# Altium schematic sheet BaseFunctions.SchDoc
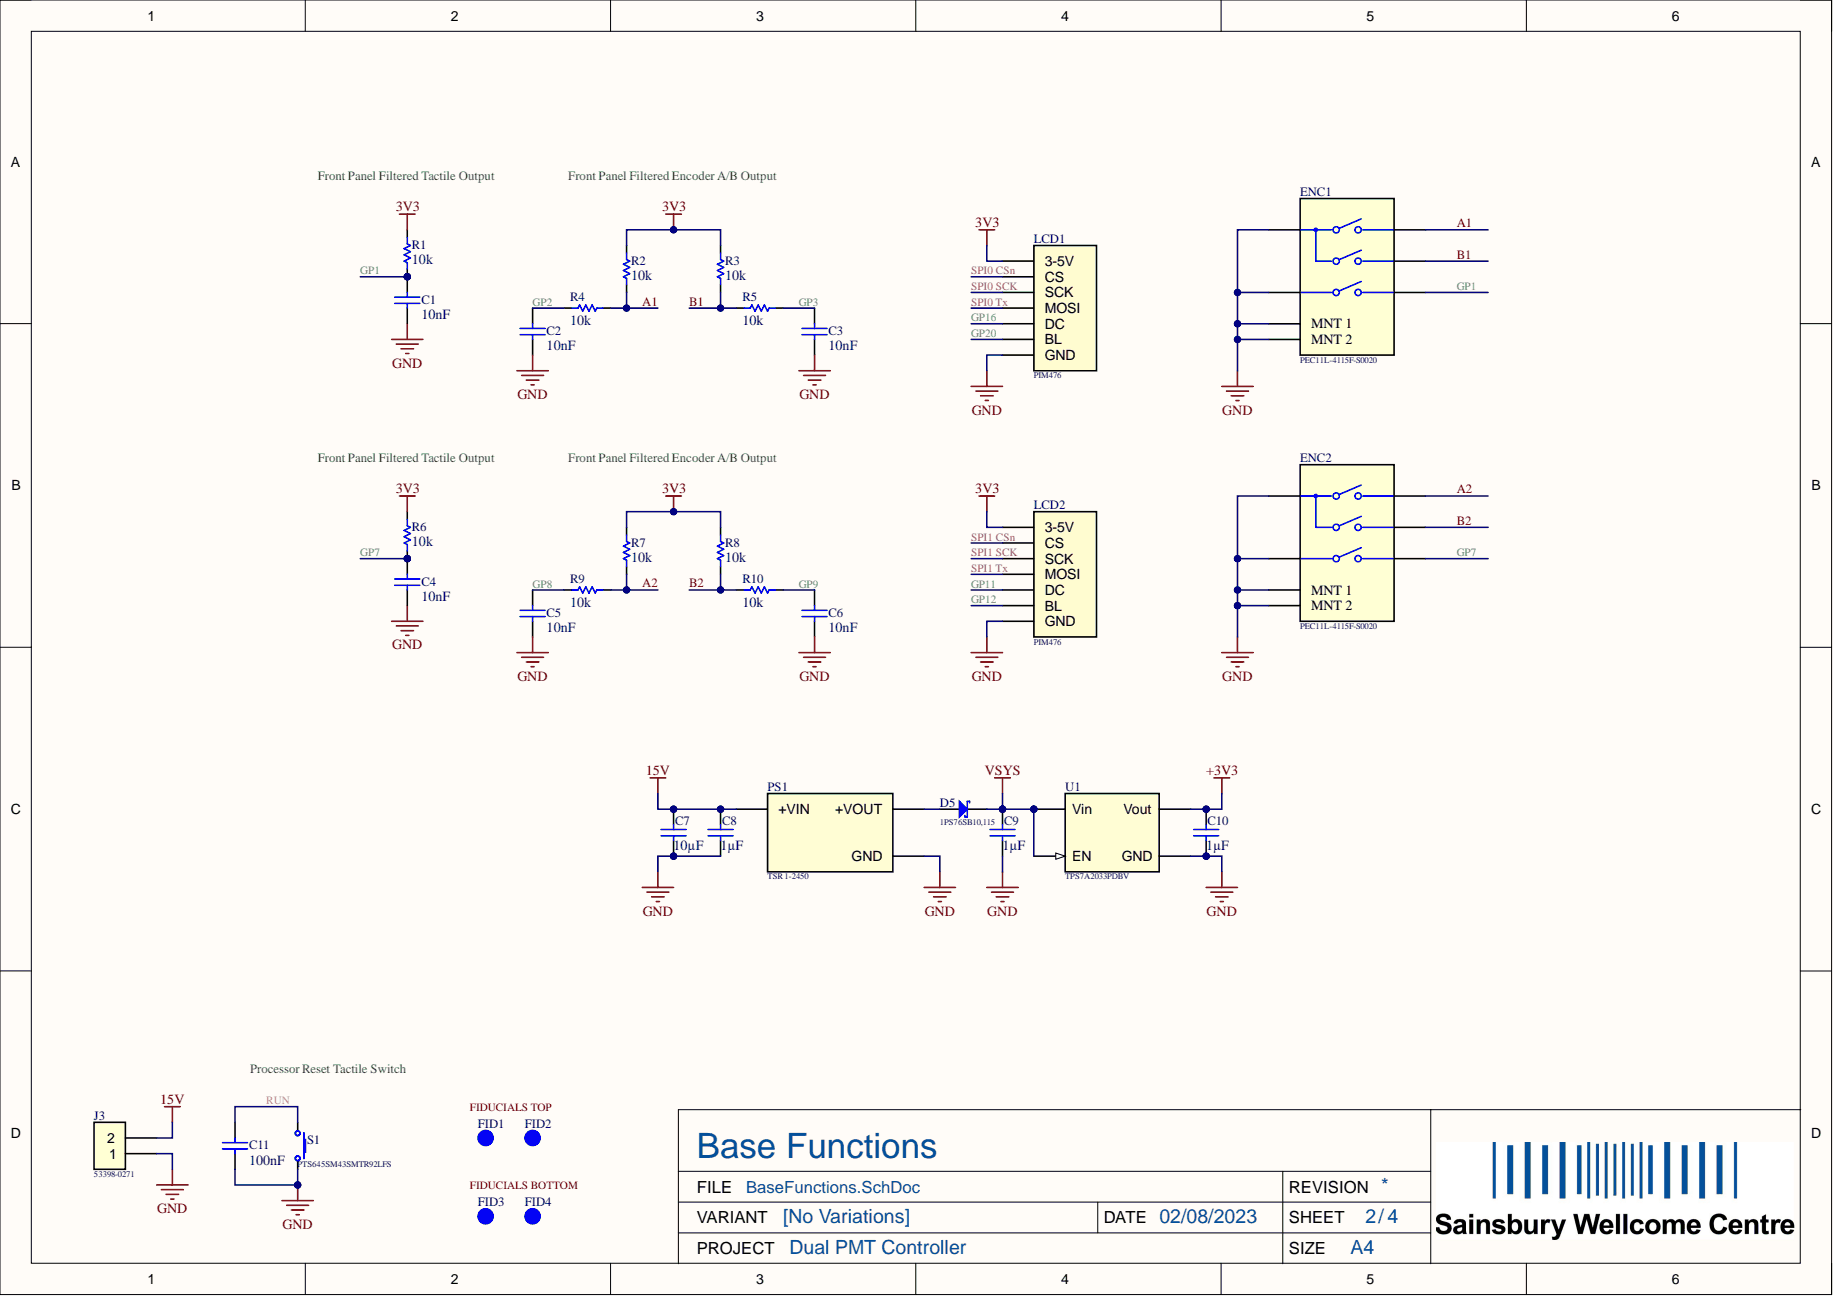
[diagram p0: BaseFunctions.SchDoc]

## Parts
C1  value 10nF  Capacitance: 10nF  [CAPC1608X90X35NL15T15]  (hidden comment: C0603-10nF-100V-10%-X7R)
C2  value 10nF  Capacitance: 10nF  [CAPC1608X90X35NL15T15]  (hidden comment: C0603-10nF-100V-10%-X7R)
C3  value 10nF  Capacitance: 10nF  [CAPC1608X90X35NL15T15]  (hidden comment: C0603-10nF-100V-10%-X7R)
C4  value 10nF  Capacitance: 10nF  [CAPC1608X90X35NL15T15]  (hidden comment: C0603-10nF-100V-10%-X7R)
C5  value 10nF  Capacitance: 10nF  [CAPC1608X90X35NL15T15]  (hidden comment: C0603-10nF-100V-10%-X7R)
C6  value 10nF  Capacitance: 10nF  [CAPC1608X90X35NL15T15]  (hidden comment: C0603-10nF-100V-10%-X7R)
C7  value 10µF  [CAPC3225X279X50NL20T25]  (hidden comment: C1210-10uF-50V-10%-X7R)
C8  value 1µF  [FP-GRM188-0_1-e0_2_0_5-IPC_B]  (hidden comment: C0603-1uF-25V-10%-X7R)
C9  value 1µF  [FP-GRM188-0_1-e0_2_0_5-IPC_B]  (hidden comment: C0603-1uF-25V-10%-X7R)
C10  value 1µF  [FP-GRM188-0_1-e0_2_0_5-IPC_B]  (hidden comment: C0603-1uF-25V-10%-X7R)
C11  value 100nF  Capacitance: 100nF  [FP-GRM188-0_1-e0_2_0_5-IPC_B]  (hidden comment: C0603-100nF-100V-10%-X7R)
D5  1PS76SB10,115  [FP-SOD323F-MFG]
ENC1  PEC11L-4115F-S0020  [FP-PEC11L-4115F-S0020-MFG]
ENC2  PEC11L-4115F-S0020  [FP-PEC11L-4115F-S0020-MFG]
FID1  FID-ROUND  [Fid_Round]  (value not drawn)  (no BOM)
FID2  FID-ROUND  [Fid_Round]  (value not drawn)  (no BOM)
FID3  FID-ROUND  [Fid_Round]  (value not drawn)  (no BOM)
FID4  FID-ROUND  [Fid_Round]  (value not drawn)  (no BOM)
J3  53398-0271  [MOLX-53398-0271_V]
LCD1  PIM476  [LCD-PIM476-TSW - 2mm9 standoff]
LCD2  PIM476  [LCD-PIM476-TSW - 2mm9 standoff]
PS1  TSR 1-2450  [CONV_TSR_1-2433]
R1  value 10k  Resistance: 10k  [FP-CPF0603-IPC_B]  (hidden comment: R0603-10k-1/16W-0.1%)
R2  value 10k  Resistance: 10k  [FP-CPF0603-IPC_B]  (hidden comment: R0603-10k-1/16W-0.1%)
R3  value 10k  Resistance: 10k  [FP-CPF0603-IPC_B]  (hidden comment: R0603-10k-1/16W-0.1%)
R4  value 10k  Resistance: 10k  [FP-CPF0603-IPC_B]  (hidden comment: R0603-10k-1/16W-0.1%)
R5  value 10k  Resistance: 10k  [FP-CPF0603-IPC_B]  (hidden comment: R0603-10k-1/16W-0.1%)
R6  value 10k  Resistance: 10k  [FP-CPF0603-IPC_B]  (hidden comment: R0603-10k-1/16W-0.1%)
R7  value 10k  Resistance: 10k  [FP-CPF0603-IPC_B]  (hidden comment: R0603-10k-1/16W-0.1%)
R8  value 10k  Resistance: 10k  [FP-CPF0603-IPC_B]  (hidden comment: R0603-10k-1/16W-0.1%)
R9  value 10k  Resistance: 10k  [FP-CPF0603-IPC_B]  (hidden comment: R0603-10k-1/16W-0.1%)
R10  value 10k  Resistance: 10k  [FP-CPF0603-IPC_B]  (hidden comment: R0603-10k-1/16W-0.1%)
S1  PTS645SM43SMTR92LFS  [FP-PTS645SM43SMTR92LFS-MFG]
U1  TPS7A2033PDBV  [FP-DBV0005A-IPC_B]

## Nets
3V3: C10.2 LCD1.1(3-5V) LCD2.1(3-5V) R1.2 R2.2 R3.2 R6.2 R7.2 R8.2 U1.5(Vout)
15V: C7.2 C8.2 J3.2 PS1.1
GND: C1.1 C2.1 C3.1 C4.1 C5.1 C6.1 C7.1 C8.1 C9.1 C10.1 C11.1 ENC1.1 ENC1.3(MNT_1) ENC1.4(MNT_2) ENC1.C ENC2.1 ENC2.3(MNT_1) ENC2.4(MNT_2) ENC2.C J3.1 LCD1.7(GND) LCD2.7(GND) PS1.2 S1.1 U1.2(GND)
VSYS: C9.2 D5.1 U1.1(Vin) U1.3(EN)
A1: ENC1.A R2.1 R4.1
A2: ENC2.A R7.1 R9.1
B1: ENC1.B R3.1 R5.2
B2: ENC2.B R8.1 R10.2
GP1: C1.2 ENC1.2 R1.1
GP2: C2.2 R4.2
GP3: C3.2 R5.1
GP7: C4.2 ENC2.2 R6.1
GP8: C5.2 R9.2
GP9: C6.2 R10.1
GP11: LCD2.5(DC)
GP12: LCD2.6(BL)
GP16: LCD1.5(DC)
GP20: LCD1.6(BL)
RUN: C11.2 S1.2
SPI0 CSn: LCD1.2(CS)
SPI0 SCK: LCD1.3(SCK)
SPI0 Tx: LCD1.4(MOSI)
SPI1 CSn: LCD2.2(CS)
SPI1 SCK: LCD2.3(SCK)
SPI1 Tx: LCD2.4(MOSI)
NetD5_2: D5.2 PS1.3

## Library data of the parts
C1-C6 = CMP-611-0030-6: 0.01µF ±10% 100V Ceramic Capacitor X7R 0603 (1608 Metric); Capacitor Type: Ceramic; Case Code (Imperial): 0603; Case Code (Metric): 1608; Case/Package: 0603; Component Kind: Standard; Construction: Flat; Depth: 0.81mm; Dielectric: X7R; Features: Soft Termination; Height: 0.94mm; Lead Free: Lead Free; Length: 1.6mm; Max Operating Temperature: 125°C; Min Operating Temperature: -55°C; Mount: Surface Mount; Package Quantity: 1; Packaging: Tape and Reel; Radiation Hardening: No; RoHS: Yes; RoHS Compliant: Yes; Series: FLEXITERM®; Termination: SMD/SMT; Thickness: 0.037inch; Tolerance: 10%; Voltage Rating: 100V; Voltage Rating (DC): 100V; Width: 0.032inch; Library Name: Sainsbury Wellcome Centre
C7 = CMP-611-0024-5: 10uF ±10% 50V Ceramic Capacitor X7R 1210 (3225 Metric); Pick&PlaceFootprint: C1210; Capacitance: 10uF; Capacitor Type: Ceramic; Case/Package: 1210; Component Kind: Standard; Dielectric: X7R; Mount: Surface Mount; RoHS: Yes; Tolerance: 10%; Voltage Rating: 50V; Library Name: Sainsbury Wellcome Centre
C8-C10 = CMP-611-0033-6: 1µF ±10% 25V Ceramic Capacitor X7R 0603 (1608 Metric); Capacitance: 1uF; Pick&PlaceFootprint: 0603_CAP; Capacitor Type: Ceramic; Case Code (Imperial): 0603; Case Code (Metric): 1608; Case/Package: 0603; Component Kind: Standard; Construction: Flat; Depth: 0.8mm; Dielectric: X7R; Height: 0.9mm; Lead Free: Lead Free; Length: 1.6mm; Material: Ceramic; Max Operating Temperature: 125°C; Min Operating Temperature: -55°C; Mount: Surface Mount; Package Quantity: 1; Packaging: Tape and Reel; Radiation Hardening: No; REACH SVHC: Yes; RoHS: Yes; RoHS Compliant: Yes; Series: GRM; Size: 0.8mm; Termination: Wraparound; Thickness: 0.035inch; Tolerance: 10%; Voltage: 25V; Voltage Rating: 25V; Voltage Rating (DC): 25V; Weight: 0.000222oz; Width: 0.8mm; Library Name: Sainsbury Wellcome Centre
C11 = CMP-611-0027-19: 0.1µF ±10% 100V Ceramic Capacitor X7R 0603 (1608 Metric); Pick&PlaceFootprint: 0603_CAP; Capacitor Type: Ceramic; Case Code (Imperial): 0603; Case Code (Metric): 1608; Case/Package: 0603; Component Kind: Standard; Construction: Flat; Depth: 0.8mm; Dielectric: X7R; Height: 0.8mm; Lead Free: Lead Free; Length: 1.6mm; Material: Ceramic; Max Operating Temperature: 125°C; Min Operating Temperature: -55°C; Mount: Surface Mount; Package Quantity: 1; Packaging: Tape and Reel; Radiation Hardening: No; REACH SVHC: No SVHC; RoHS: Yes; RoHS Compliant: Yes; Series: GRM; Size: 0.8mm; Termination: SMD/SMT; Thickness: 0.035inch; Tolerance: 10%; Voltage: 100V; Voltage Rating: 100V; Voltage Rating (DC): 100V; Weight: 0.000222oz; Width: 0.8mm; Library Name: Sainsbury Wellcome Centre
D5 = CMP-821-0008-2: Rectifier Diode, Schottky, 1 Phase, 1 Element, 0.2A, Silicon; Max Output current: 200mA; Number of Elements: 1; Package Body Material: Plastic; Qualification Status: Not Qualified; Time @ Peak Reflow Temperature-Max (s): 40s; Component Kind: Standard; Diode Element Material: SILICON; Diode Type: RECTIFIER; JESD-30 Code: R-PDSO-G2; JESD-609 Code: e3; Max Operating Temperature: 125°C; Moisture Sensitivity Level: 1; Mount: Surface Mount; Package Shape: Rectangular; Package Style: SMALL OUTLINEMeter; PartNumber: 1PS76SB10,115; Peak Reflow Temperature (Cel): 260°C; Pins: 2; Reach SVHC Compliant: Yes; RoHS Compliant: Yes; Surface Mount: Yes; Technology: SCHOTTKY; Terminal Finish: Tin; Terminal Form: Gull Wing; Terminal Position: DUAL; Library Name: Sainsbury Wellcome Centre
ENC1 ENC2 = CMP-B00-0003-3: LOW PROFILE ENCODER, 11 MM, CONTACT RATING 10 MA - 5 VDC; Built in Switch: Yes; Encoder Type: Mechanical; Number of Channels: 2; Output Type: Quadrature,Incremental; Pulses per Revolution: 20; Component Kind: Standard; Detent: Yes; Height: 12mm; Length: 19.5mm; Max Operating Temperature: 80°C; Min Operating Temperature: -25°C; Mount: Through Hole,Panel,PCB; Orientation: Vertical; Package Quantity: 75; Packaging: Bulk; RoHS Compliant: Yes; Series: PEC11; Termination: PC Pin; Width: 11.8mm; Library Name: Sainsbury Wellcome Centre
FID1-FID4 = CMP-C00-0001-2: Round Fiducial; Library Name: Sainsbury Wellcome Centre
J3 = CMP-370-0034-4: Board Connector, 2 Contact(s), 1 Row(s), Male, Straight, 0.049 inch Pitch, Surface Mount Terminal, Locking, Natural Insulator, Receptacle; Board Mounting Option: FITTING NAIL; Body Breadth: 0.165inch; Body Depth: 0.185inch; Body Length: 0.285inch; Contact Pattern: RECTANGULAR; Contact Resistance: 20mR; Contact Style: Blade Pin; Dielectric Withstanding Voltage (AC): 250V; DIN Conformance: No; Durability: 30Cycles; Insulation Resistance: 100MR; Mating Information: MULTIPLE MATING PARTS AVAILABLE; Number Of Connectors: 1; Number of Contacts: 2; Option: General Purpose; Plating Thickness: 39uin; Polarization Key: POLARIZED HOUSING; Rated Current (Signal): 1A; Reference Standard: UL; Reliability: COMMERCIAL; Body/Shell Style: RECEPTACLE; Component Kind: Standard; Connector Type: BOARD CONNECTOR; Contact Finish - Mating: MATTE TIN (39) OVER NICKEL; Contact Finish - Termination: Tin (Sn); Contact Gender: Male; Contact Material: Bronze; Filter Feature: No; Flammability Rating: UL94 V-0; IEC Conformance: No; Insulation Color: Natural; Insulation Material: Polyamide; JESD-609 Code: e3; Mating Contact Pitch: 0.049inch; Max Operating Temperature: 85°C; MIL Conformance: No; Min Operating Temperature: -40°C; Mixed Contacts: No; Mount: Board; Mounting Option 1: LOCKING; Mounting Style: STRAIGHT; Number Of PCB Rows: 1; Number of Rows Loaded: 1; PartNumber: 53398-0271; PCB Contact Pattern: RECTANGULAR; REACH SVHC: Yes; RoHS Compliant: Yes; Terminal Pitch: 1.25mm; Termination: SMD/SMT; Library Name: Sainsbury Wellcome Centre
LCD1 LCD2 = CMP-A00-0000-5: 1.3" Spi Colour Lcd (240X240) Breakout; Pick&PlaceFootprint: NA; Component Kind: Standard; PartNumber: PIM476; Library Name: Sainsbury Wellcome Centre
PS1 = CMP-A10-0008-4: DC/DC Converter, ITE, 1 Output, 5 W, 5 V, 1 A, TSR 1 Series; Component Kind: Standard; PartNumber: TSR 1-2450; Library Name: Sainsbury Wellcome Centre
R1-R10 = CMP-633-0002-15: Surface Mount Resistor 10k Ohm 1/16 W 0.1% 0603 (1608 Metric); Max Power Dissipation: 62.5 mW; Number of Resistors: 1; Pick&PlaceFootprint: R0603; Power Rating: 62.5mW; Temperature Coefficient: 25ppm/°C; Voltage Rating: 50V; Case Code (Imperial): 0603; Case Code (Metric): 1608; Case/Package: 0603; Component Kind: Standard; Composition: Thin Film; ELV: Compliant; Height: 0.45mm; Length: 1.6mm; Max Operating Temperature: 155°C; Min Operating Temperature: -55°C; Mount: Surface Mount; Number of Pins: 2; Number of Terminations: 2; Packaging: Tape and Reel; REACH SVHC: No SVHC; Resistance Tolerance: 0.1%; Resistor Type: Thin Film; RoHS: Yes; RoHS Compliant: Yes; Series: CPF; Size Code: 0603; Technology: Thin Film; Termination: Solder; Tolerance: 0.1%; Voltage Rating (DC): 50V; Weight: 7.1E-05oz; Width: 0.8mm; Library Name: Sainsbury Wellcome Centre
S1 = CMP-B00-0009-1: Keypad Switch, 1 Switches, SPST, Momentary-tactile, 0.05A, 12VDC, 1.57N, 4 PCB Hole Cnt, Solder Terminal, Surface Mount-straight; Case/Package: PT645; Component Kind: Standard; Mount: Surface Mount; PartNumber: PTS645SM43SMTR92LFS; Library Name: Sainsbury Wellcome Centre
U1 = CMP-970-0027-1: Fixed Positive LDO Regulator, 3.3V, 0.14V Dropout, PDSO5; Pick&PlaceFootprint: SOT-23; Component Kind: Standard; PartNumber: TPS7A2033PDBV; Library Name: Sainsbury Wellcome Centre
